# Altium schematic sheet Power.SchDoc
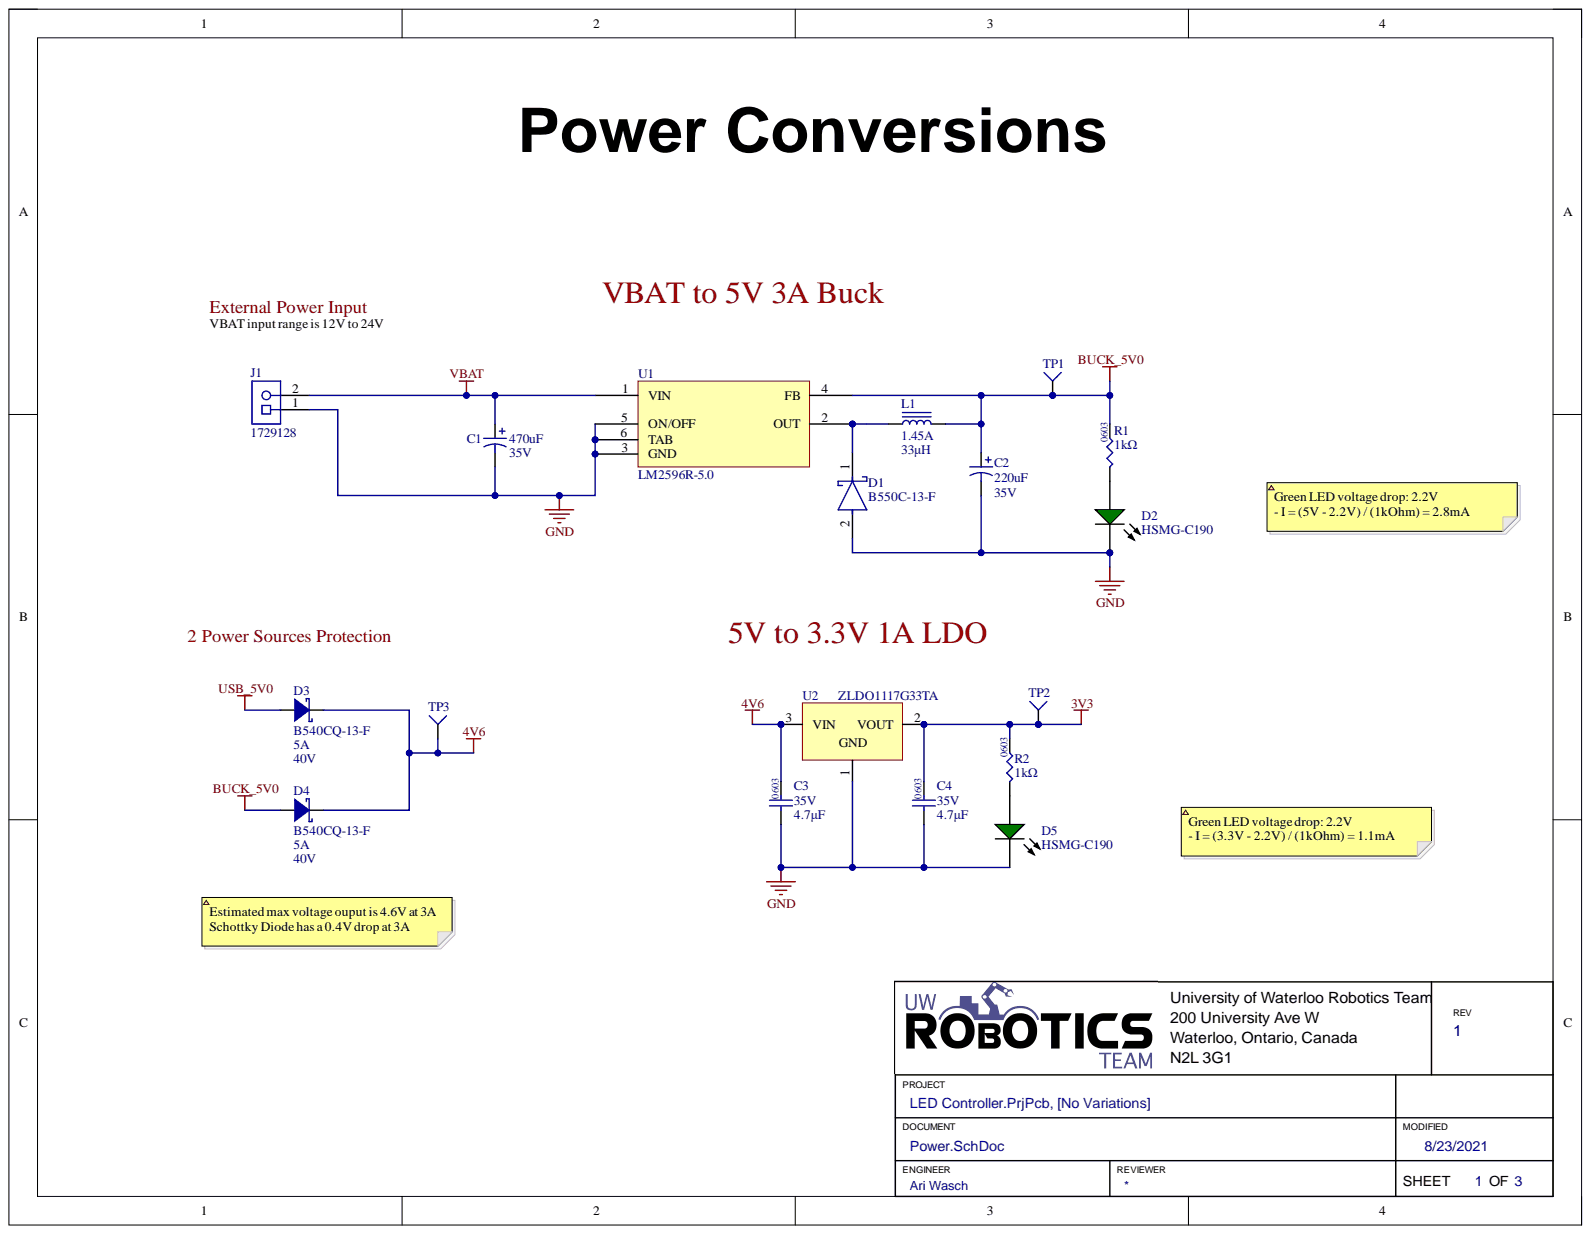
[diagram p0: Power.SchDoc]

## Parts
C1  Capacitance: 470uF  Voltage: 35V  [CAPAE1030X1100N]  (hidden comment: CAP_470uF_35V_ALUM)
C2  220uF  Voltage: 35V  [CAP220uF35V8.2x10]
C3  value 4.7μF  Package/Footprint: 0603  Voltage Rating: 35V  [CAP0603]  (hidden comment: CAP_4.7uF_35V_0603)
C4  value 4.7μF  Package/Footprint: 0603  Voltage Rating: 35V  [CAP0603]  (hidden comment: CAP_4.7uF_35V_0603)
D1  B550C-13-F  [FP-SMC-MFG]
D2  HSMG-C190  [AVAG-HSMX-C190_V]
D3  Current: 5A  Manufacturer Part Number 1: B540CQ-13-F  [DO214AB SMC]  (hidden comment: DIO_SCHOTTKY_40V_5A)
D4  Current: 5A  Manufacturer Part Number 1: B540CQ-13-F  [DO214AB SMC]  (hidden comment: DIO_SCHOTTKY_40V_5A)
D5  HSMG-C190  [AVAG-HSMX-C190_V]
J1  1729128  [PHOE-1729128-2_V]
L1  DC Current: 1.45A  Inductance: 33μH  [IND_CKCS6045]  (hidden comment: IND_33uH_1.45A)
R1  value 1kΩ  Package/Footprint: 0603  [RES0603]  (hidden comment: RES_1kΩ_0603_5%)
R2  value 1kΩ  Package/Footprint: 0603  [RES0603]  (hidden comment: RES_1kΩ_0603_5%)
TP1  TESTPOINT_1MM_SQUARE  [TESTPOINT_SQUARE_1MM]  (value not drawn)  (no BOM)
TP2  TESTPOINT_1MM_SQUARE  [TESTPOINT_SQUARE_1MM]  (value not drawn)  (no BOM)
TP3  TESTPOINT_1MM_SQUARE  [TESTPOINT_SQUARE_1MM]  (value not drawn)  (no BOM)
U1  LM2596R-5.0  [LM2596S-5.0]
U2  LDO_3.3V_1A  [ZLDO1117G33TA]  (value not drawn)

## Nets
3V3: C4.2 R2.2 TP2.1 U2.2
4V6: C3.2 D3.2 D4.2 TP3.1 U2.3
BUCK_5V0: C2.1 D4.1 L1.2 R1.2 TP1.1 U1.4(FB)
GND: C1.2 C2.2 C3.1 C4.1 D1.2 D2.2 D5.2 J1.1 U1.3(GND) U1.5(ON/OFF) U1.6(TAB) U2.1
USB_5V0: D3.1
VBAT: C1.1 J1.2 U1.1(VIN)
NetD1_1: D1.1 L1.1 U1.2(OUT)
NetD2_1: D2.1 R1.1
NetD5_1: D5.1 R2.1

## Library data of the parts
C1 = CAP_470uF_35V_ALUM: CAP ALUM 470uF 20% 35V SMD; Manufacturer 1: ROQANG; Manufacturer Part Number 1: RVT1V471M1010; Component Kind: Standard; Datasheet Link: https://datasheet.lcsc.com/szlcsc/2004031004_ROQANG-RVT1V471M1010_C72519.pdf; Height: 11mm; LCSC Number: C72519; Library Name: UWRT_Schematic_Library_2020.SchLib
C2 = CAP_220uF_35V_ALUM: 220uF 35V Cap; Manufacturer 1: KNSCHA; Manufacturer Part Number: RVT220UF35V67RV0024; Capacitance: 220 uF; Component Kind: Standard; LCSC Number: C310848; Package: 8x10.2mm; Supplier 1: LCSC; Library Name: UWRT_Schematic_Library_2020.SchLib
C3 C4 = CAP_4.7uF_35V_0603: CAP CER 4.7UF 35V X6S 0603; Manufacturer 1: TDK; Manufacturer Part Number 1: C1608X5R1V475KT000E; Dielectric: X5R; Component Kind: Standard; LCSC Number: C329940; Mounting Type: SMD; Supplier 1: LCSC; Library Name: UWRT_Schematic_Library_2020.SchLib
D1 = CMP-2000-05906-2: DIODE SCHOTTKY 50V 5A SMC; Capacitance: 300pF; Element Configuration: Single; Forward Voltage: 700mV; Max Forward Surge Current (Ifsm): 100A; Peak Reverse Current: 500uA; Polarity: Standard; Average Rectified Current: 5A; Case/Package: SMC; Component Kind: Standard; Contact Plating: Tin; Current Rating: 5A; Forward Current: 5A; Height: 2.5mm; LCSC Number: C134461; Lead Free: Lead Free; Length: 7.11mm; Library Name: Altium Content Vault; Max Operating Temperature: 125°C; Max Repetitive Reverse Voltage (Vrrm): 50V; Max Reverse Voltage (DC): 50V; Min Operating Temperature: -55°C; Mount: Surface Mount; Number of Pins: 2; Output Current: 5A; Package Quantity: 3000; Packaging: Tape and Reel; Peak Non-Repetitive Surge Current: 100A; Radiation Hardening: No; REACH SVHC: No SVHC; Termination: SMD/SMT; Voltage Rating (DC): 50V; Weight: 0.007408oz; Width: 6.22mm
D2 D5 = CMP-1744-00002-1: Chip LED, Green, 2.2 V, -40 to 85 degC, 2-Pin SMD, RoHS, Tape and Reel; Access Time: 3500 ns; Case\Package: SOIC; Clock Frequency: 100 KHz; Density: 32 kb; Frequency: 400 KHz; Interface: Serial; Max Supply Voltage: 5.5 V; Min Supply Voltage: 1.7 V; Nominal Supply Current: 2 mA; Component Kind: Standard; ComponentLink1Description: Manufacturer URL; ComponentLink1URL: http://www.avagotech.com/; ComponentLink2Description: Datasheet; ComponentLink2URL: http://docs.avagotech.com/docs/AV02-0551EN; ComponentLink3Description: Package Specification; ComponentLink3URL: http://www.fairchildsemi.com/dwg/MA/MA03D.pdf; DatasheetVersion: Mar-12; Height: 1.5 mm; LCSC Number: C188729; Length: 5 mm; Library Name: Altium Content Vault; Max Clock Frequency: 100 KHz; Max Operating Temperature: 85 degC; Min Operating Temperature: -40 degC; Mount: Surface Mount; Package Quantity: 3000; PackageDescription: 2-Pin Surface Mount Device, Body 1.6 x 0.8 mm, Height 0.9 mm; PackageReference: HSMX-C190; Packaging: Tape and Reel; Pins: 8; Radiation Hardening: No; REACH SVHC: No SVHC; RoHSCompliant: true; Width: 4 mm
D3 D4 = DIO_SCHOTTKY_40V_5A: DIODE SCHOTTKY 40V 5A SMC; Manufacturer 1: Diodes; Capacitance: 300pF; Forward Voltage: 550mV; Max Forward Surge Current (Ifsm): 100A; Peak Reverse Current: 500uA; Component Kind: Standard; Current Rating: 5A; Forward Current: 5A; LCSC Number: C105556; Max Repetitive Reverse Voltage (Vrrm): 40V; Max Reverse Voltage (DC): 40V; Mounting Type: SMD; Package/Footprint: SMC; Peak Non-Repetitive Surge Current: 100A; Supplier 1: Digi-Key; Supplier Part Number 1: B540C-FDIDKR-ND; Voltage: 40V; Voltage Rating (DC): 40V; Library Name: UWRT_Schematic_Library_2020.SchLib
J1 = CMP-2000-05815-1: PCB Terminal Block, 13.5 A, 400 V, 2-Pin THD, RoHS, Bulk; Manufacturer: Phoenix Contact; Current Rating: 13500mA; No. of Positions: 2; No. of Rows: 1; Package Version: 03/2015; Pitch: 5.08mm; Voltage Rating: 400V; Component Kind: Standard; Datasheet URL: https://datasheet.ciiva.com/16046/1729128-16046181.pdf; Datasheet Version: 12/2013; LCSC Number: C91153; Library Name: Altium Content Vault; Manufacturer URL: http://www.phoenixcontact.com/; Mounting Technology: ThroughHole; Orientation: Horizontal; Package Description: 2-Pin Through Hole Device, Body 8.1 x 10.16 mm, Pitch 5.08 mm; Package Reference: 1729128-2; Package URL: https://www.phoenixcontact.com/online/portal/pi/pxc/product_detail_page/!ut/p/b1/3VdJjqNKFDxLH8AFycySyWY2ozFsEPOMMQaDOX1XedGL_1Vdm5YXJleknhSKeBkvI6EA8ncAo3AYoDhBQGco6KN7VURTdemj9us_IEKEZo_YHiPAEWEFmDGOHMORkqKYCORBZxgL7ZoaZYcbFFUZ3fqxSY6GOuxydWUuHqz1ynmMFygu583Bob0Ewr6Sd_l-5m-kAueHiB0jpRwZwe58wwtkpxtMYd_LmGcDRU02dRNEyWt6Y2ti5pSyJ_reIkzIulrqApdnbT11V5jnCKbYNCvhEM1MCpPByat_X379-iThf08CI5GfOPqfBeS3BRoBOdD55AKVv0iLwCMq-2BrzXWSGRH7pUGD9uwXQjiph3xL0DKQwdGa0JImiIOmBNOestqgwTHSSs2oOep-78apyXQBvNi8ZLaFjvjWvcaUEBu0MC6Wbe-d6mB0FAebo2lUWinqYaZs5DAYzV0RP9yitUjnGJ0iI9xhZkT42OCQNUJJhkgWINNQLP2JFP6OpMg3JCVg70jqHT0lvKOnhHf0lPuOnnLf0VPuWx6_dxwUIvwk5WMkT0kLL1mh61l2yZVSzG3pYV_bqo-mj6uMKwKwdnnW8Jh5RTOJzMOA5c-eJDaZQw4mTMPH02PvS_ZAqNVjVTo2WyYLkwH_KU1mmmG5kjRhDqPXLwwVHvVOjCM60-fpXvTDfMGW1PVFVS1r4e4yVDk4ZdNbkUHvqPqQSeian4UD39fNKmachqdJ1NJbyk8rXT9mXTvPk3oE5meulKGgiruPJek-4I9hTT6G8ZLOyRSm2RRVbThERQad5OS28hdt4YW4vG0stgYYzsWsK4_7y6DZMjJSCqri1kyiZR5vD7pwIrNR7QwPHTcLTI5qT7eRlpHrdGiupYlqQDJ7zy_CND8fWWBoLRXxk1RVcXiBQwbTD4Xi7KL-FuO94t9xaqweXzH; Packing: Bulk; PartNumber: 1729128; RoHS: TRUE
L1 = IND_33uH_1.45A: INDUCTOR 33UH 1.45A SMD ROHS; Manufacturer 1: CENKER; Manufacturer Part Number 1: CKCS6045-33uH; DC Resistance (DCR): 137mΩ; Component Kind: Standard; LCSC Number: C354635; RMS Current (Irms): 1.45A; Library Name: UWRT_Schematic_Library_2020.SchLib
R1 R2 = RES_1kΩ_0603_5%: RES 1K OHM 5% 1/10W 0603; Manufacturer 1: Yageo; Manufacturer Part Number 1: RC0603JR-071KL; Component Kind: Standard; LCSC Number: C14676; Mounting Type: SMD; Supplier 1: Digi-Key; Supplier Part Number 1: 311-1.0KGRCT-ND; Library Name: UWRT_Schematic_Library_2020.SchLib
TP1-TP3 = TESTPOINT_1MM_SQUARE: TESTPOINT 1MM SQUARE; Library Name: UWRT_Schematic_Library_2020.SchLib
U1 = LM2596R-5.0: IC REG BUCK 5V 3A TO220-5; Manufacturer 1: HTC Korea TAEJIN Tech; Max Voltage Input: 40V; Min Voltage Input: 4.5V; Component Kind: Standard; Current Output: 3A; Datasheet: https://lcsc.com/search?q=WJ127-5.0-3P; LCSC Number: C77782; Manufacturer Part Number 1: LM2596R-5.0; Supplier 1: LCSC; Voltage Output: 5V; Library Name: UWRT_Schematic_Library_2020.SchLib
U2 = LDO_3.3V_1A: IC REG LINEAR 3.3V 1A SOT223; Manufacturer 1: Diodes Incorperated; Input Voltage (Max): 18V; Package/Footprint: SOT-224; Voltage Dropout (Max): 1.3V@1A; Component Kind: Standard; LCSC Number: C444421; Manufacturer Part Number 1: ZLDO1117G33TA; Mounting Type: Surface Mount; Operating Temperature: -40°C - 125°C; Output Current: 1A; Output Voltage (Fixed): 3.3V; Supplier 1: Digi-Key; Supplier Part Number 1: ZLDO1117G33TA; Library Name: UWRT_Schematic_Library_2020.SchLib
